# Altium schematic sheet S7CAS-PLEIADES-CARTE-MERE_P02_MOTOR_CTRL.SchDoc
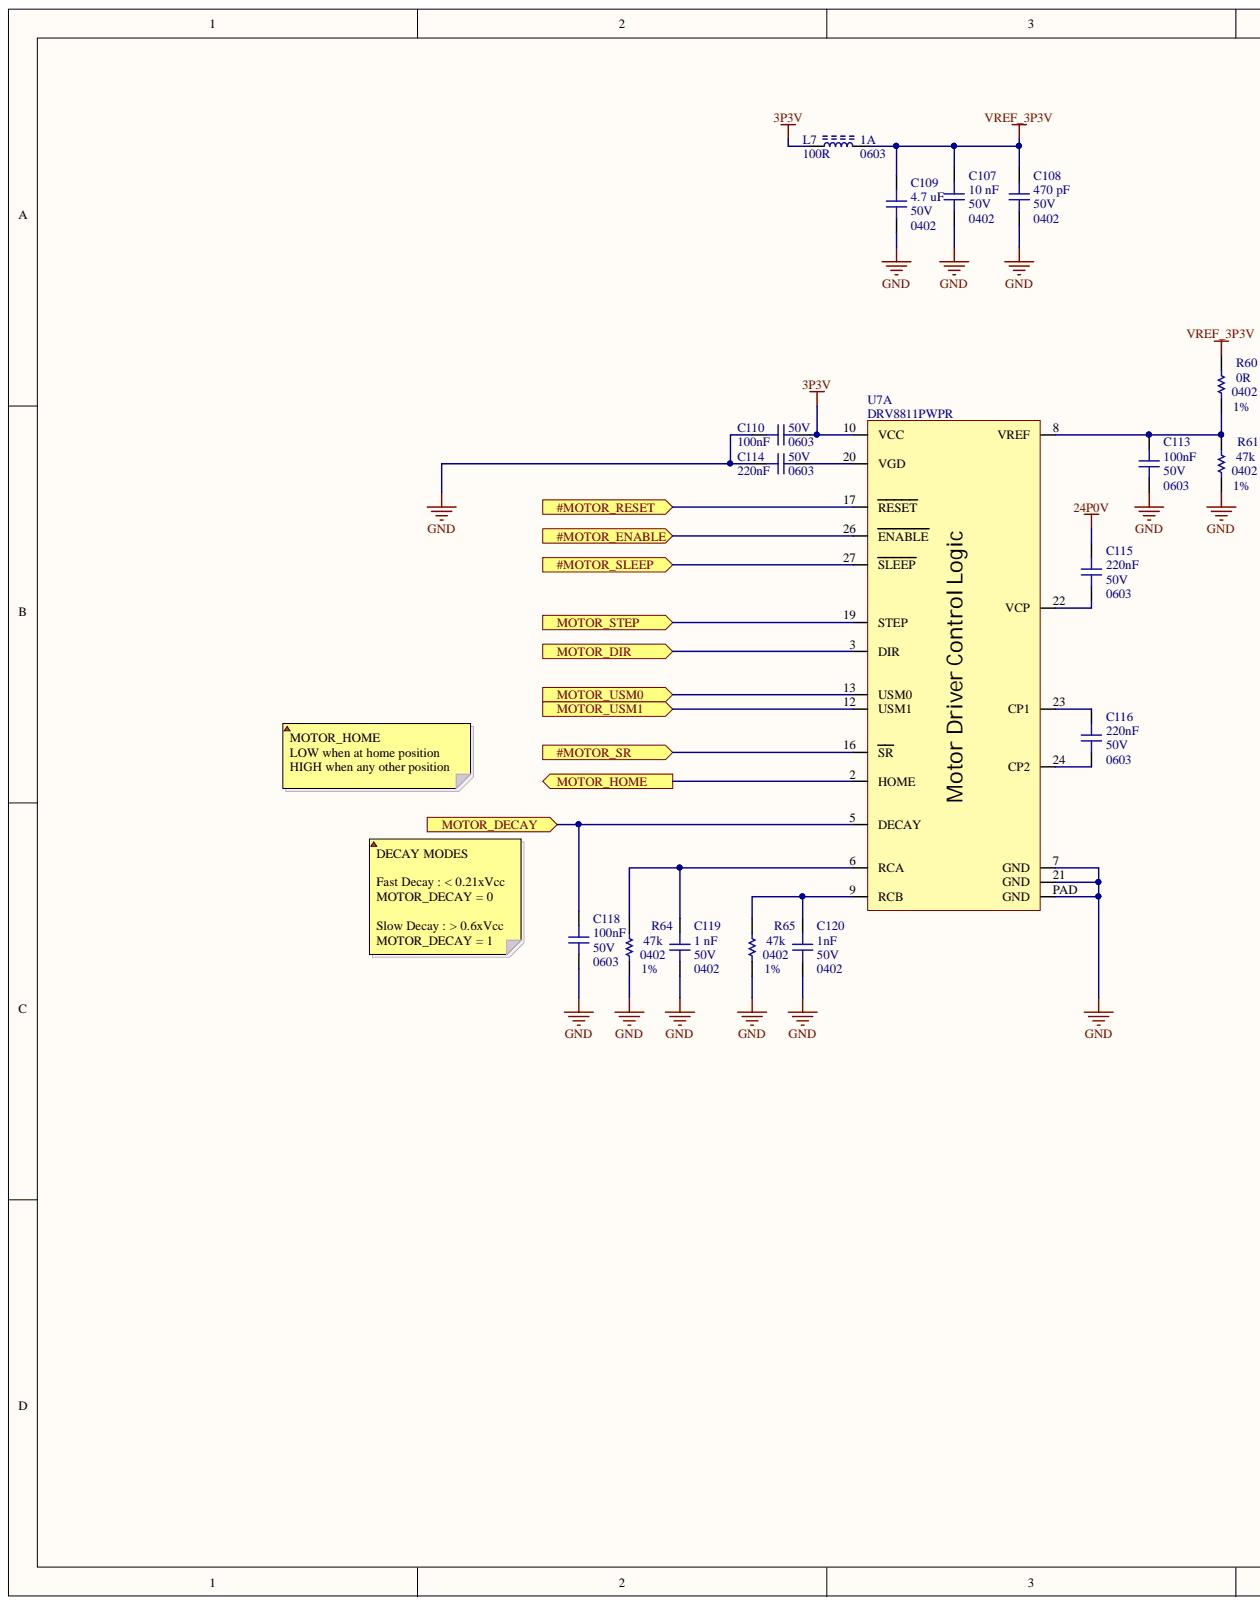
[diagram p0: S7CAS-PLEIADES-CARTE-MERE_P02_MOTOR_CTRL.SchDoc, left]
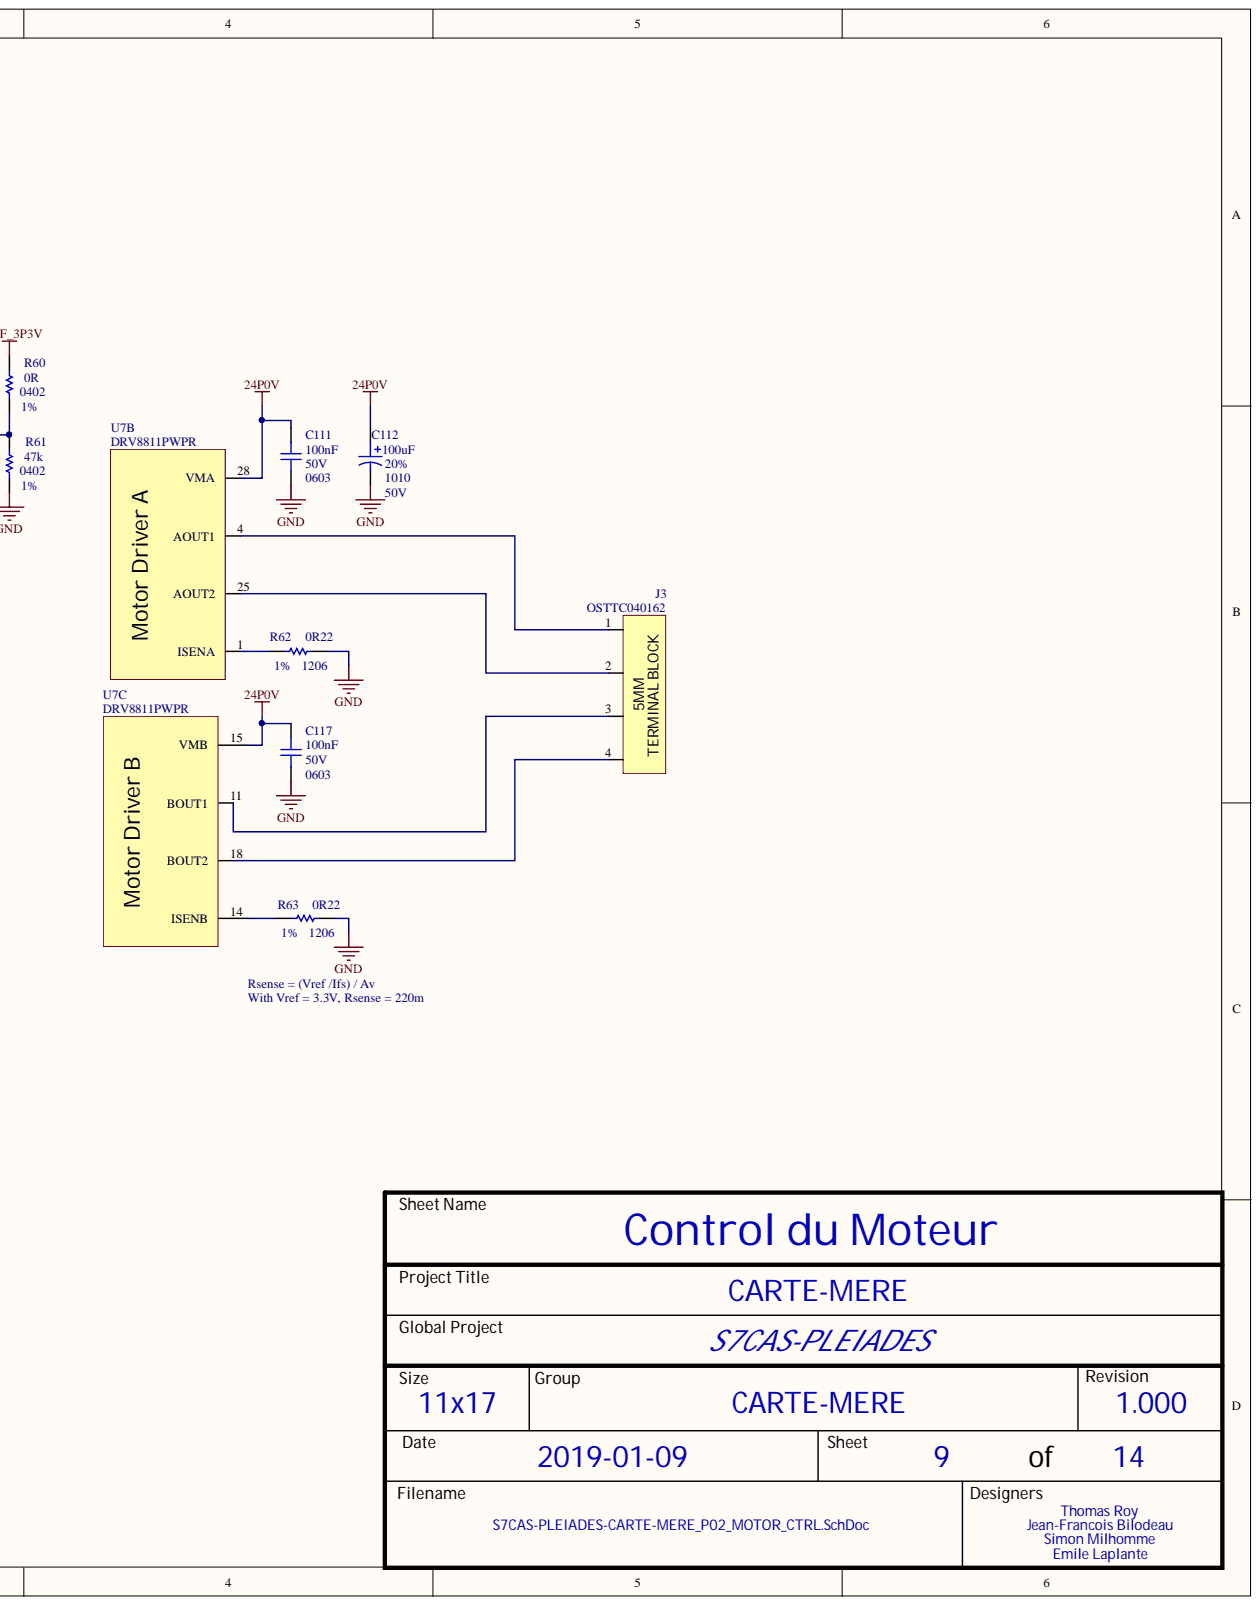
[diagram p1: S7CAS-PLEIADES-CARTE-MERE_P02_MOTOR_CTRL.SchDoc, right]

## Parts
C107  value 10 nF  Package: 0402  Voltage: 50V  [CAP_0402]  (hidden comment: CAP - 0402)
C108  value 470 pF  Package: 0402  Voltage: 50V  [CAP_0402]  (hidden comment: CAP - 0402)
C109  value 4.7 uF  Package: 0402  Voltage: 50V  [CAP_0402]  (hidden comment: CAP - 0402)
C110  value 100nF  Package: 0603  Voltage: 50V  [CAP_0603]  (hidden comment: CAP - 1206)
C111  value 100nF  Package: 0603  Voltage: 50V  [CAP_0603]  (hidden comment: CAP - 1206)
C112  value 100uF  Package: 1010  Precision: 20%  Voltage: 50V  [CAP_ALU_1010_vishay]  (hidden comment: MAL215099101E3)
C113  value 100nF  Package: 0603  Voltage: 50V  [CAP_0603]  (hidden comment: CAP - 1206)
C114  value 220nF  Package: 0603  Voltage: 50V  [CAP_0603]  (hidden comment: CAP - 1206)
C115  value 220nF  Package: 0603  Voltage: 50V  [CAP_0603]  (hidden comment: CAP - 1206)
C116  value 220nF  Package: 0603  Voltage: 50V  [CAP_0603]  (hidden comment: CAP - 1206)
C117  value 100nF  Package: 0603  Voltage: 50V  [CAP_0603]  (hidden comment: CAP - 1206)
C118  value 100nF  Package: 0603  Voltage: 50V  [CAP_0603]  (hidden comment: CAP - 1206)
C119  value 1 nF  Package: 0402  Voltage: 50V  [CAP_0402]  (hidden comment: CAP - 1206)
C120  value 1nF  Package: 0402  Voltage: 50V  [CAP_0402]  (hidden comment: CAP - 1206)
J3  OSTTC040162  [CONN_TERMINAL_OSTTA040161]
L7  value 100R  Current: 1A  Package: 0603  [FER_0603]  (hidden comment: FER - 0603)
R60  value 0R  Package: 0402  Precision: 1%  [RES_0402]  (hidden comment: RES - 0402)
R61  value 47k  Package: 0402  Precision: 1%  [RES_0402]  (hidden comment: RES - 0402)
R62  value 0R22  Package: 1206  Precision: 1%  [RES_0402]  (hidden comment: RES - 0402)
R63  value 0R22  Package: 1206  Precision: 1%  [RES_0402]  (hidden comment: RES - 0402)
R64  value 47k  Precision: 1%  Voltage: 50V  [RES_0402]  (hidden comment: RES - 0402)
R65  value 47k  Precision: 1%  [RES_0402]  (hidden comment: RES - 0402)
U7  DRV8811PWPR  [POW_MOTOR_DRV8811PWPR]  (parts A,B,C)

## Ports
#MOTOR_ENABLE, #MOTOR_RESET, #MOTOR_SLEEP, #MOTOR_SR, MOTOR_DECAY, MOTOR_DIR, MOTOR_HOME, MOTOR_STEP, MOTOR_USM0, MOTOR_USM1

## Nets
3P3V: C110.1 L7.2 U7.10(VCC)
24P0V: C111.2 C112.1 C115.2 C117.2 U7.15(VMB) U7.28(VMA)
GND: C107.1 C108.1 C109.1 C110.2 C111.1 C112.2 C113.1 C114.2 C117.1 C118.1 C119.1 C120.1 R61.2 R62.1 R63.1 R64.2 R65.2 U7.7(GND) U7.21(GND) U7.PAD(GND)
VREF_3P3V: C107.2 C108.2 C109.2 L7.1 R60.1
#MOTOR_ENABLE: U7.26(ENABLE) ; port #MOTOR_ENABLE
#MOTOR_RESET: U7.17(RESET) ; port #MOTOR_RESET
#MOTOR_SLEEP: U7.27(SLEEP) ; port #MOTOR_SLEEP
#MOTOR_SR: U7.16(SR) ; port #MOTOR_SR
MOTOR_DECAY: C118.2 U7.5(DECAY) ; port MOTOR_DECAY
MOTOR_DIR: U7.3(DIR) ; port MOTOR_DIR
MOTOR_HOME: U7.2(HOME) ; port MOTOR_HOME
MOTOR_STEP: U7.19(STEP) ; port MOTOR_STEP
MOTOR_USM0: U7.13(USM0) ; port MOTOR_USM0
MOTOR_USM1: U7.12(USM1) ; port MOTOR_USM1
NetC113_2: C113.2 R60.2 R61.1 U7.8(VREF)
NetC114_1: C114.1 U7.20(VGD)
NetC115_1: C115.1 U7.22(VCP)
NetC116_1: C116.1 U7.24(CP2)
NetC116_2: C116.2 U7.23(CP1)
NetC119_2: C119.2 R64.1 U7.6(RCA)
NetC120_2: C120.2 R65.1 U7.9(RCB)
NetJ3_1: J3.1 U7.4(AOUT1)
NetJ3_2: J3.2 U7.25(AOUT2)
NetJ3_3: J3.3 U7.11(BOUT1)
NetJ3_4: J3.4 U7.18(BOUT2)
NetR62_2: R62.2 U7.1(ISENA)
NetR63_2: R63.2 U7.14(ISENB)

## Library data of the parts
C107-C109 = CAP - 0402: Precision: 10%; Component Kind: Standard; Description: CAP - 0402; Library Name: S7CAS_Lib2019_REV1_00.IntLib; CreatedBy: Jonathan Bouchard
C110 C111 C113-C120 = CAP - 1206: Precision: 10%; Component Kind: Standard; Description: CAP - 1206; Library Name: S7CAS_Lib2019_REV1_00.IntLib; CreatedBy: Jonathan Bouchard
C112 = CAP_ALU_MAL215099101E3: CAP - ALU 100uF 20% 50V - 1010; Current: 490mA; Mfg: Vishay Dale; Component Kind: Standard; HelpURL: http://www.vishay.com/docs/28395/150crz.pdf; Library Name: S7CAS_Lib2019_REV1_00.IntLib; Mfg Part Number: MAL215099101E3; Supplier 1: Digikey; Supplier Part Number 1: 4399PHDKR-ND; Temperature: -55°C to 125°C; CreatedBy: Jonathan Bouchard
J3 = CONN_TERMINAL_OSTTC040162: CONN -  TERMINAL BLOCK 4POS 5MM - TH; Mfg: On Shore Technology Inc.; Component Kind: Standard; HelpURL: http://www.on-shore.com/wp-content/uploads/2015/09/osttcxx0162.pdf; Library Name: S7CAS_Lib2019_REV1_00.IntLib; Mfg Part Number: OSTTC040162; Package: TH-4; Supplier 1: Digikey; Supplier Part Number 1: ED2602-ND; Temperature: -30°C ~ 105°C; CreatedBy: Jonathan Bouchard
R60-R63 R65 = RES - 0402: Power: 1/16W; Voltage: 50V; Component Kind: Standard; Description: RES - 0402; Library Name: S7CAS_Lib2019_REV1_00.IntLib; CreatedBy: Jonathan Bouchard
  R65: Package: 0402
R64 = RES - 0402: Power: 1/16W; Component Kind: Standard; Description: RES - 0402; Library Name: S7CAS_Lib2019_REV1_00.IntLib; Package: 0402; CreatedBy: Jonathan Bouchard
U7 = POW_MOTOR_DRV8811PWPR: POW - STEPPER MOTOR DRIVER - SOP-28; Mfg: Texas Instrument; Voltage: 3 V ~ 5.5 V / 8 V ~ 38 V; Component Kind: Standard; HelpURL: http://www.ti.com/lit/ds/symlink/drv8811.pdf; Library Name: S7CAS_Lib2019_REV1_00.IntLib; Mfg Part Number: DRV8811PWPR; Package: SOP-28; Supplier 1: Digikey; Supplier Part Number 1: 296-24591-1-ND; Temperature: -40°C ~ 150°C (TJ); CreatedBy: Jonathan Bouchard
L7 = FER - 0603: Component Kind: Standard; Description: FER - 0603; Library Name: S7CAS_Lib2019_REV1_00.IntLib; CreatedBy: Jonathan Bouchard
